# Altium schematic sheet lv_AirConditioner_power.SchDoc(lv_AirConditioner_power)
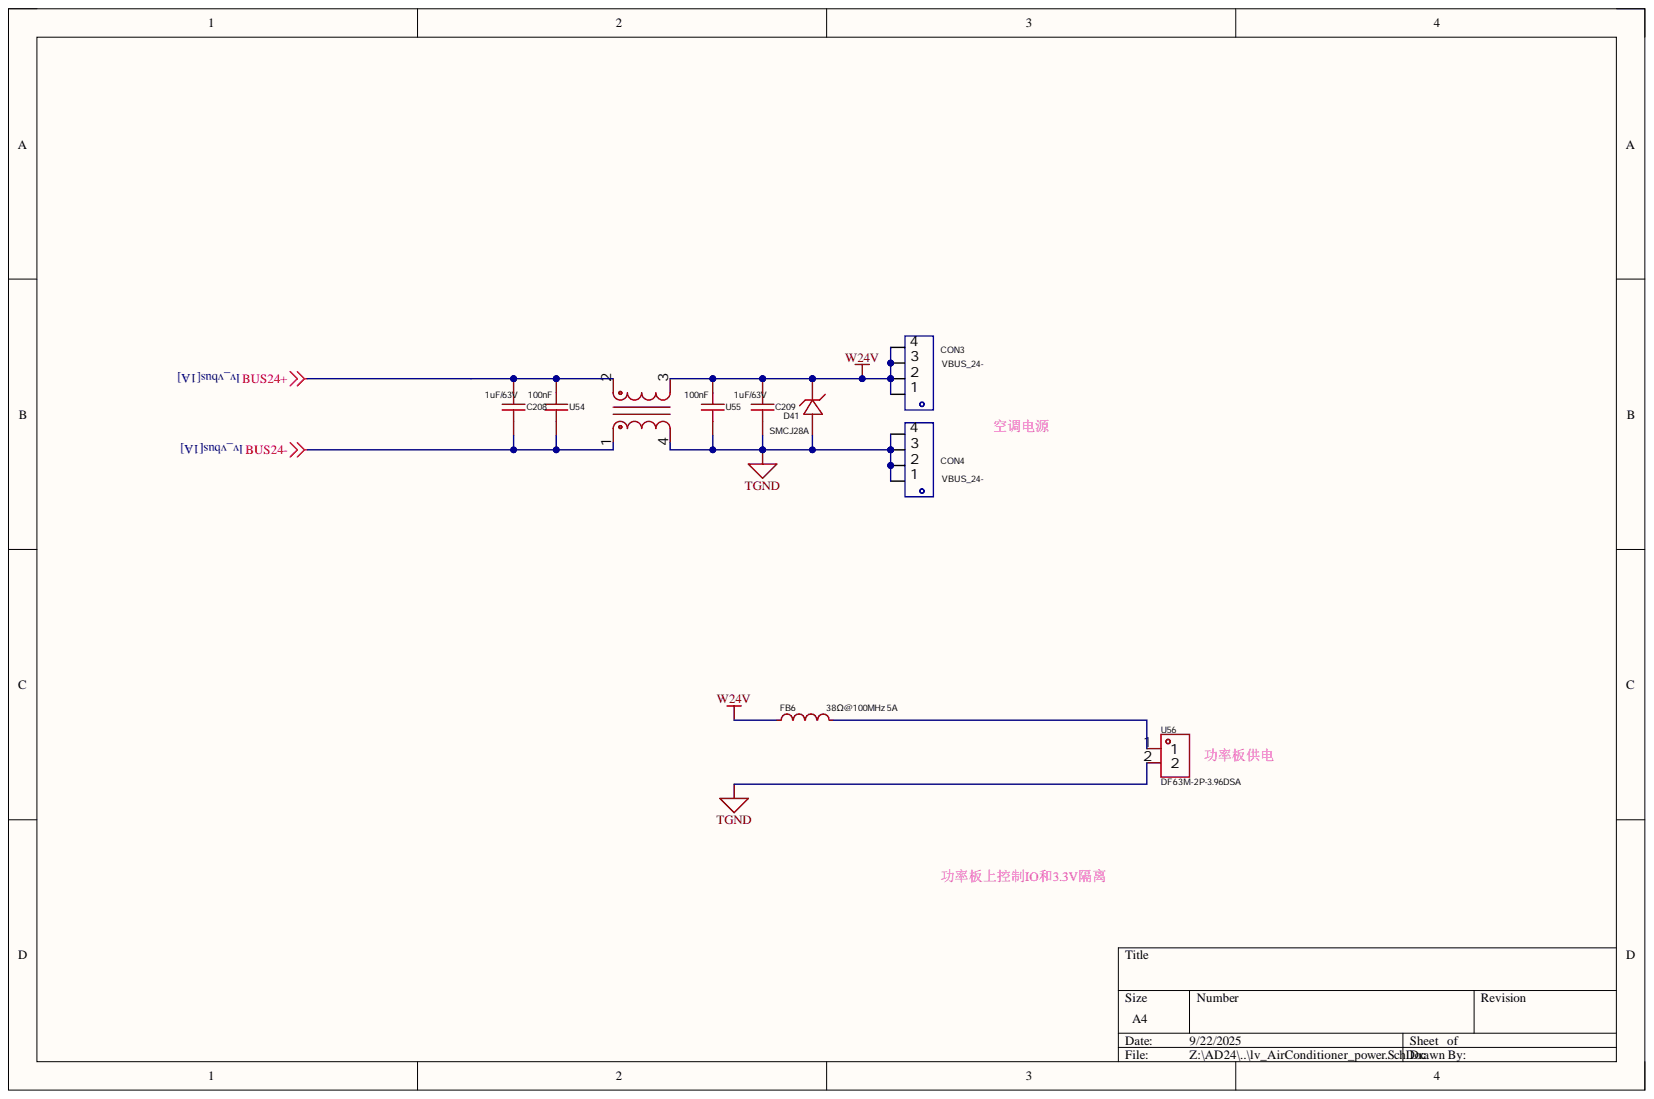
[diagram p0: lv_AirConditioner_power.SchDoc]

## Parts
C208  1uF/63V  [CAP-TH_L7.2-W5.0-P5.00-D1.0]
C209  1uF/63V  [CAP-TH_L7.2-W5.0-P5.00-D1.0]
CON3  VBUS_24-  [CONN-TH_T44001]
CON4  VBUS_24-  [CONN-TH_T44001]
D41  SMCJ28A  [SMC_L6.9-W5.9-LS7.9-RD]
FB6  PZ3216D380-5R0TF  [L1206]  (value not drawn)
L35  FC-HLF2018-5mH-25A-LF  [IND-TH_4P-L22.5-W14.5-P13.00-D1.2-LS10.80]  (value not drawn)
U54  100nF  [CAP-TH_L7.2-W2.5-P5.00-D1.0]
U55  100nF  [CAP-TH_L7.2-W2.5-P5.00-D1.0]
U56  DF63M-2P-3.96DSA  [CONN-TH_2P-P3.96_DF63M-2P-3.96DSA]

## Nets
BUS24+: C208.2 L35.2 U54.2
BUS24-: C208.1 L35.1 U54.1
TGND: C209.1 CON4.1 CON4.2 CON4.3 CON4.4 D41.2 L35.4 U55.1 U56.2
W24V: C209.2 CON3.1 CON3.2 CON3.3 CON3.4 D41.1 FB6.1 L35.3 U55.2
NetFB6_2: FB6.2 U56.1

## Library data of the parts
C208 C209 = MEB105J63V82CB0235: 精度±5%,额定电压63V,金属化聚酯; Manufacturer: KNSCHA(科尼盛); Component Kind: Standard; Library Name: HiPin_Library_AD.IntLib; Manufacturer_Part_Number: MEB105J63V82CB0235
CON3 CON4 = T44001: Manufacturer: XFCN(兴飞); Add into BOM: yes; Component Kind: Standard; Convert to PCB: yes; Datasheet: https://atta.szlcsc.com/upload/public/pdf/source/20200107/C481448_4214B4EB74A46B70BD82BA8A456D4EDD.pdf; Device: T44001; JLCPCB Part Class: Extended Part; LCSC Part Name: 四脚 M4 二件套; Library Name: HiPin_Library_AD.IntLib; Manufacturer Part: T44001; Supplier: LCSC; Supplier Footprint: 插件; Supplier Part: C481447; Symbol: T44001
D41 = SMCJ28A_C693618: Manufacturer: FTR(乔光电子); Add into BOM: yes; Breakdown Voltage: 31.1V; Maximum Clamping Voltage: 45.4V; Peak Pulse Current (Ipp)@10/1000us: 33.1A; polarity: 单向; Reverse Stand-Off Voltage (Vrwm): 28V; Type: TVS; Component Kind: Standard; Convert to PCB: yes; Datasheet: https://atta.szlcsc.com/upload/public/pdf/source/20200702/C693547_038122F463C15F3D55BC5385490E6510.pdf; Device: SMCJ28A_C693618; JLCPCB Part Class: Extended Part; LCSC Part Name: SMCJ28A; Library Name: HiPin_Library_AD.IntLib; Manufacturer Part: SMCJ28A; Supplier: LCSC; Supplier Footprint: SMC(DO-214AB); Supplier Part: C693618; Symbol: SMCJ28A_C693618
FB6 = BLM31PG601SN1L: Manufacturer: Sunlord(顺络); Add into BOM: yes; Circuits: 1; Current Rating: 1.5A; DC Resistance: 80mΩ; Impedance @ Frequency: 600Ω@100MHz; Tolerance: ±25%; Component Kind: Standard; Convert to PCB: yes; Datasheet: https://atta.szlcsc.com/upload/public/pdf/source/20180326/C88997_FE7208E239BA92CF7577D85CF2703D30.pdf; Device: BLM31PG601SN1L; JLCPCB Part Class: Extended Part; LCSC Part Name: 38Ω@100MHz 5A; Library Name: HiPin_Library_AD.IntLib; Manufacturer Part: PZ3216D380-5R0TF; Supplier: LCSC; Supplier Footprint: 1206; Supplier Part: C16902; Symbol: BLM31PG601SN1L
L35 = FC-HLF2018-5mH-25A-LF: Manufacturer: FC(方成); Add into BOM: yes; Current Rating: 25A; DC Resistance (DCR): 6.5mΩ; Inductance @ Frequency: 5mH; Component Kind: Standard; Convert to PCB: yes; Datasheet: https://atta.szlcsc.com/upload/public/pdf/source/20221207/3992F2C35BDE318E91CE6ED02A57E846.pdf; Device: FC-HLF2018-5mH-25A-LF; Impedance @ Frequency: -; JLCPCB Part Class: Extended Part; LCSC Part Name: 插件共模滤波器; Library Name: HiPin_Library_AD.IntLib; Manufacturer Part: FC-HLF2018-5mH-25A-LF; Number of Lines: -; Operating Temperature: -40℃~+125℃; Supplier: LCSC; Supplier Footprint: 插件; Supplier Part: C5307518; Symbol: FC-HLF2018-5MH-25A-LF; Voltage Rating: -; Voltage Rating - DC: -; Withstanding Voltage: -
U54 U55 = MEB104J63V82CB0236: Manufacturer: KNSCHA(科尼盛); Add into BOM: yes; Dielectric Material: 金属化聚酯; Tolerance: ±5%; Voltage Rated: 63V; Component Kind: Standard; Convert to PCB: yes; Datasheet: https://atta.szlcsc.com/upload/public/pdf/source/20220613/95E40529FEB7A3E68EC83AD5A7304622.pdf; Device: MEB104J63V82CB0236; JLCPCB Part Class: Extended Part; LCSC Part Name: 100nF ±5% 63V; Library Name: HiPin_Library_AD.IntLib; Manufacturer Part: MEB104J63V82CB0236; Operating Temperature: -40℃~+110℃; Supplier: LCSC; Supplier Footprint: 插件,P=5mm; Supplier Part: C3034535; Symbol: MEB104J63V82CB0236; Value: 100nF
U56 = DF63M-2P-3.96DSA: Manufacturer: HRS(广濑); Add into BOM: yes; Component Kind: Standard; Convert to PCB: yes; Datasheet: https://atta.szlcsc.com/upload/public/pdf/source/20221205/6AC71532B9B9566170A63CE16B2C46ED.pdf; Device: DF63M-2P-3.96DSA; JLCPCB Part Class: Extended Part; LCSC Part Name: DF63M-2P-3.96DSA; Library Name: HiPin_Library_AD.IntLib; Manufacturer Part: DF63M-2P-3.96DSA; Supplier: LCSC; Supplier Footprint: 插件,P=3.96mm; Supplier Part: C5254350; Symbol: DF63M-2P-3.96DSA
